# Altium schematic sheet Top.SchDoc(Top)
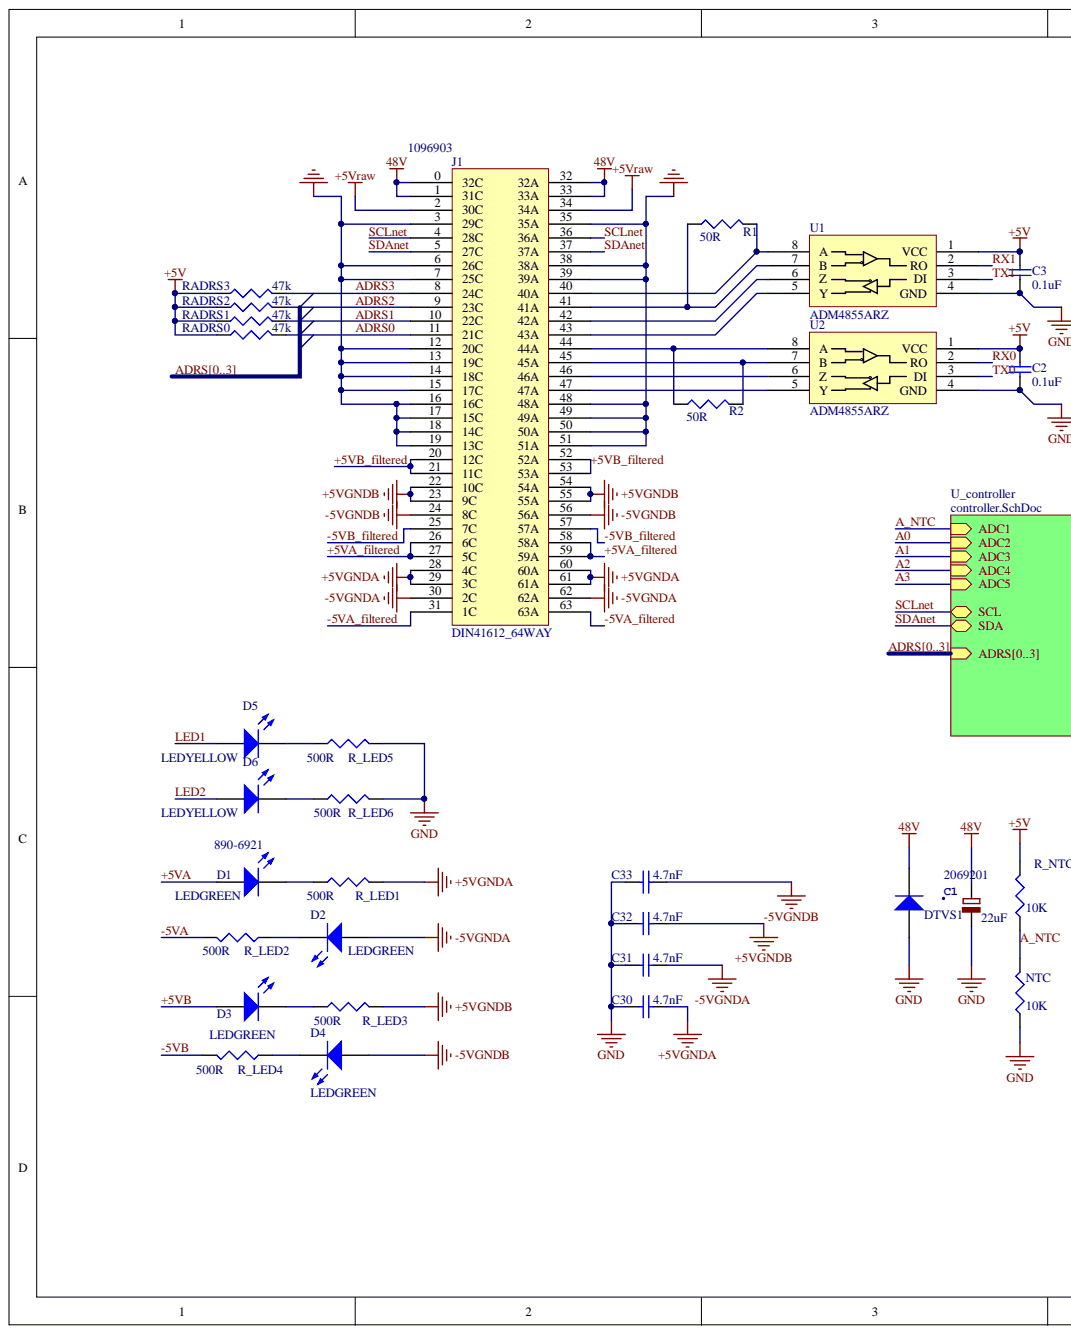
[diagram p0: Top.SchDoc, left]
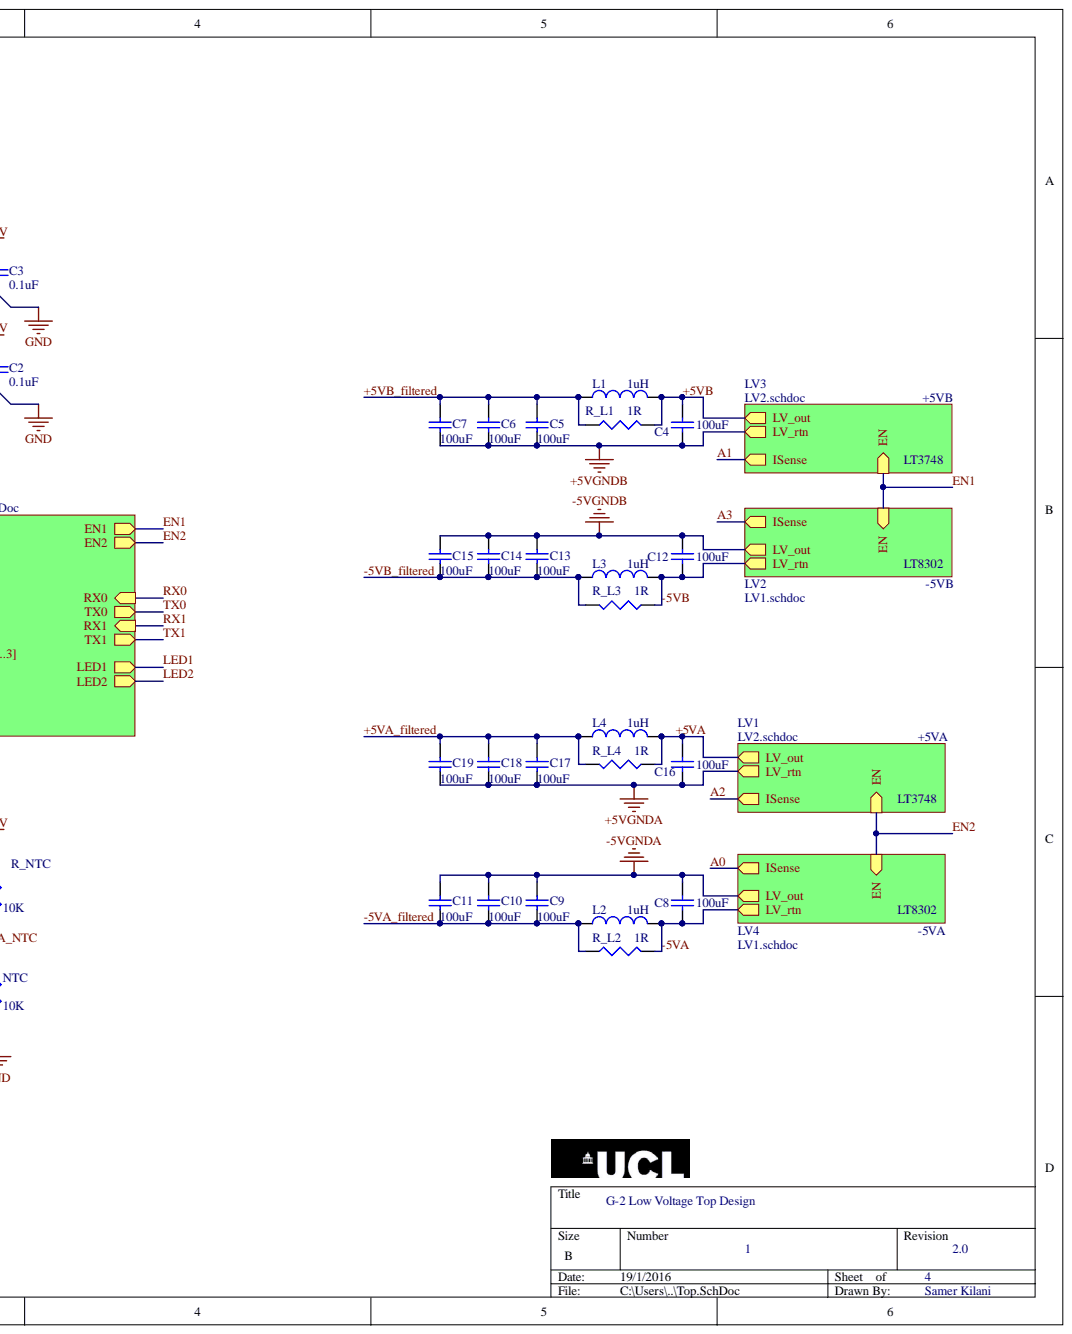
[diagram p1: Top.SchDoc, right]

## Parts
C1  value 22uF  Supplier Part Number 1: 2069201  [PANASONIC_D]
C2  value 0.1uF  [1608[0603]]  (hidden comment: Cap Semi)
C3  value 0.1uF  [1608[0603]]  (hidden comment: Cap Semi)
C4  value 100uF  [C1210]  (hidden comment: Cap Semi)
C5  value 100uF  [C1210]  (hidden comment: Cap Semi)
C6  value 100uF  [C1210]  (hidden comment: Cap Semi)
C7  value 100uF  [C1210]  (hidden comment: Cap Semi)
C8  value 100uF  [C1210]  (hidden comment: Cap Semi)
C9  value 100uF  [C1210]  (hidden comment: Cap Semi)
C10  value 100uF  [C1210]  (hidden comment: Cap Semi)
C11  value 100uF  [C1210]  (hidden comment: Cap Semi)
C12  value 100uF  [C1210]  (hidden comment: Cap Semi)
C13  value 100uF  [C1210]  (hidden comment: Cap Semi)
C14  value 100uF  [C1210]  (hidden comment: Cap Semi)
C15  value 100uF  [C1210]  (hidden comment: Cap Semi)
C16  value 100uF  [C1210]  (hidden comment: Cap Semi)
C17  value 100uF  [C1210]  (hidden comment: Cap Semi)
C18  value 100uF  [C1210]  (hidden comment: Cap Semi)
C19  value 100uF  [C1210]  (hidden comment: Cap Semi)
C30  value 4.7nF  [1812]  (hidden comment: Cap Semi)
C31  value 4.7nF  [1812]  (hidden comment: Cap Semi)
C32  value 4.7nF  [1812]  (hidden comment: Cap Semi)
C33  value 4.7nF  [1812]  (hidden comment: Cap Semi)
D1  LEDGREEN  Supplier Part Number 1: 890-6921  [LEDGREEN]
D2  LEDGREEN  [LEDGREEN]
D3  LEDGREEN  [LEDGREEN]
D4  LEDGREEN  [LEDGREEN]
D5  LEDYELLOW  [LEDYELLOW]
D6  LEDYELLOW  [LEDYELLOW]
DTVS1  SMBJ48A  [DO-214AA]  (value not drawn)
J1  DIN41612_64WAY  Supplier Part Number 1: 1096903  [09 03 164 6919]
L1  value 1uH  [MPLC0730]  (hidden comment: Inductor)
L2  value 1uH  [MPLC0730]  (hidden comment: Inductor)
L3  value 1uH  [MPLC0730]  (hidden comment: Inductor)
L4  value 1uH  [MPLC0730]  (hidden comment: Inductor)
NTC  value 10K  [J1-0603]
R1  value 50R  [J1-0603]
R2  value 50R  [J1-0603]
R_L1  value 1R  [J1-0603]  (hidden comment: Res3)
R_L2  value 1R  [J1-0603]  (hidden comment: Res3)
R_L3  value 1R  [J1-0603]  (hidden comment: Res3)
R_L4  value 1R  [J1-0603]  (hidden comment: Res3)
R_LED1  value 500R  [J1-0603]  (hidden comment: Res3)
R_LED2  value 500R  [J1-0603]  (hidden comment: Res3)
R_LED3  value 500R  [J1-0603]  (hidden comment: Res3)
R_LED4  value 500R  [J1-0603]  (hidden comment: Res3)
R_LED5  value 500R  [J1-0603]  (hidden comment: Res3)
R_LED6  value 500R  [J1-0603]  (hidden comment: Res3)
R_NTC  value 10K  [J1-0603]
RADRS0  value 47k  [J1-0603]  (hidden comment: Res3)
RADRS1  value 47k  [J1-0603]  (hidden comment: Res3)
RADRS2  value 47k  [J1-0603]  (hidden comment: Res3)
RADRS3  value 47k  [J1-0603]  (hidden comment: Res3)
U1  ADM4855ARZ  [SOICN8]
U2  ADM4855ARZ  [SOICN8]

## Nets
48V: C1.1 DTVS1.1 J1.0(32C) J1.1(31C) J1.32(32A) J1.33(33A)
+5V: C2.2 C3.2 R_NTC.2 RADRS0.1 RADRS1.1 RADRS2.1 RADRS3.1 U1.1(VCC) U2.1(VCC)
+5VGNDA: C16.2 C17.2 C18.2 C19.2 C30.2 J1.28(4C) J1.29(3C) J1.60(60A) J1.61(61A) R_LED1.2
+5VGNDB: C4.1 C5.1 C6.1 C7.1 C32.2 J1.22(10C) J1.23(9C) J1.54(54A) J1.55(55A) R_LED3.2
+5VRAW: J1.2(30C) J1.34(34A)
-5VGNDA: C8.2 C9.2 C10.2 C11.2 C31.2 D2.2 J1.30(2C) J1.62(62A)
-5VGNDB: C12.1 C13.1 C14.1 C15.1 C33.2 D4.2 J1.24(8C) J1.56(56A)
GND: C1.2 C2.1 C3.1 C30.1 C31.1 C32.1 C33.1 DTVS1.2 J1.3(29C) J1.6(26C) J1.7(25C) J1.12(20C) J1.13(19C) J1.14(18C) J1.15(17C) J1.16(16C) J1.17(15C) J1.18(14C) J1.19(13C) J1.35(35A) J1.38(38A) J1.39(39A) J1.48(48A) J1.49(49A) J1.50(50A) J1.51(51A) NTC.1 R_LED5.2 R_LED6.2 U1.4(GND) U2.4(GND)
+5VA: C16.1 D1.2 L4.2 R_L4.1
+5VA_FILTERED: C17.1 C18.1 C19.1 J1.26(6C) J1.27(5C) J1.58(58A) J1.59(59A) L4.1 R_L4.2
+5VB: C4.2 D3.2 L1.2 R_L1.2
+5VB_FILTERED: C5.2 C6.2 C7.2 J1.20(12C) J1.21(11C) J1.52(52A) J1.53(53A) L1.1 R_L1.1
-5VA: C8.1 L2.2 R_L2.2 R_LED2.2
-5VA_FILTERED: C9.1 C10.1 C11.1 J1.31(1C) J1.63(63A) L2.1 R_L2.1
-5VB: C12.2 L3.2 R_L3.1 R_LED4.2
-5VB_FILTERED: C13.2 C14.2 C15.2 J1.25(7C) J1.57(57A) L3.1 R_L3.2
A_NTC: NTC.2 R_NTC.1
ADRS0: J1.11(21C) RADRS0.2  [also labelled ADRS]
ADRS1: J1.10(22C) RADRS1.2  [also labelled ADRS]
ADRS2: J1.9(23C) RADRS2.2  [also labelled ADRS]
ADRS3: J1.8(24C) RADRS3.2  [also labelled ADRS]
LED1: D5.2
LED2: D6.2
RX0: U2.2(RO)
RX1: U1.2(RO)
SCLNET: J1.4(28C) J1.36(36A)
SDANET: J1.5(27C) J1.37(37A)
TX0: U2.3(DI)
TX1: U1.3(DI)
NetD1_1: D1.1 R_LED1.1
NetD2_1: D2.1 R_LED2.1
NetD3_1: D3.1 R_LED3.1
NetD4_1: D4.1 R_LED4.1
NetD5_1: D5.1 R_LED5.1
NetD6_1: D6.1 R_LED6.1
NetJ1_40: J1.40(40A) R1.2 U1.8(A)
NetJ1_41: J1.41(41A) R1.1 U1.7(B)
NetJ1_42: J1.42(42A) U1.6(Z)
NetJ1_43: J1.43(43A) U1.5(Y)
NetJ1_44: J1.44(44A) R2.1 U2.8(A)
NetJ1_45: J1.45(45A) R2.2 U2.7(B)
NetJ1_46: J1.46(46A) U2.6(Z)
NetJ1_47: J1.47(47A) U2.5(Y)
A0:
A1:
A2:
A3:
EN1:
EN2:

## Library data of the parts
C1 = CPOL-EUD: PartNo: EMZA500ADA220MF61G; Component Kind: Standard; Library Name: G2_LV.SCHLIB; Supplier 1: Farnell
C2 C3 = Cap Semi: Capacitor (Semiconductor SIM Model); PartNo: CC0603KRX7R9BB104; Component Kind: Standard; LatestRevisionDate: 29-May-2009; LatestRevisionNote: IPC-7351 Footprint Added.; Library Name: Miscellaneous Devices.IntLib; PackageDescription: Chip Capacitor; PackageReference: C1206; Published: 8-Jun-2000; Signal Integrity: Cap Semi; Simulation: CAP; Publisher: Altium Limited
C4 C8 C12 C16 = Cap Semi: Capacitor (Semiconductor SIM Model); PartNo: C3225X5R0J107M250AC; Component Kind: Standard; LatestRevisionDate: 29-May-2009; LatestRevisionNote: IPC-7351 Footprint Added.; Library Name: Miscellaneous Devices.IntLib; PackageDescription: Chip Capacitor; PackageReference: C1206; Published: 8-Jun-2000; Signal Integrity: Cap Semi; Simulation: CAP; Publisher: Altium Limited
C5-C7 C9-C11 C13-C15 C17-C19 = Cap Semi: Capacitor (Semiconductor SIM Model); PartNo: CL32A107MPVNNNE; Component Kind: Standard; LatestRevisionDate: 29-May-2009; LatestRevisionNote: IPC-7351 Footprint Added.; Library Name: Miscellaneous Devices.IntLib; PackageDescription: Chip Capacitor; PackageReference: C1206; Published: 8-Jun-2000; Signal Integrity: Cap Semi; Simulation: CAP; Publisher: Altium Limited
C30-C33 = Cap Semi: Capacitor (Semiconductor SIM Model); PartNo: C1812C472KDRACTU; Component Kind: Standard; LatestRevisionDate: 29-May-2009; LatestRevisionNote: IPC-7351 Footprint Added.; Library Name: G2_LV.SCHLIB; PackageDescription: Chip Capacitor; PackageReference: C1206; Published: 8-Jun-2000; Signal Integrity: Cap Semi; Simulation: CAP; Publisher: Altium Limited
D1-D4 = LEDGREEN: PartNo: 550-0207F; Component Kind: Standard; Library Name: G2_LV.SCHLIB
  D1: Supplier 1: RS-Components
D5 D6 = LEDYELLOW: PartNo: 550-0307F; Component Kind: Standard; Library Name: G2_LV.SCHLIB
DTVS1 = SMBJ: TVS; Note: Pin 2 is not connected and not drawn.; Component Kind: Standard; ComponentLink1Description: Manufacturer Link; ComponentLink1URL: http://www.infineon.com/; ComponentLink2Description: Datasheet; ComponentLink2URL: http://www.infineon.com/upload/Document/cmc_upload/documents/080/656/bas116series.pdf; DatasheetVersion: Oct-1999; LatestRevisionDate: 29-May-2009; LatestRevisionNote: IPC-7351 Footprint Added.; Library Name: G2_LV.IntLib; PackageDescription: 3-Pin SOT-23 Package 0.95 mm Pitch, 2.5 mm Lead Span; PackageReference: SOT-23; PackageVersion: Sep-2001; PartNo: SMBJ48A; Published: 21-Mar-2003; Publisher: Altium Limited
J1 = DIN41612_64WAY: PartNo: 0903 164 6921; Component Kind: Standard; Library Name: G2_LV.SCHLIB; Supplier 1: Farnell
L1-L4 = Inductor: PartNo: MPLC0730L1R0; Code_IEC: 1005; Code_JEITA: 0402; Component Kind: Standard; Description: Inductor; LatestRevisionDate: 29-May-2009; LatestRevisionNote: IPC-7351 Footprint Added.; Library Name: G2_LV.SCHLIB; PackageDescription: Chip Inductor; PackageReference: 0402-A; Published: 8-Jun-2000; Publisher: Altium Limited
NTC = Res3: Resistor; PartNo: NCP18XH103J03RB; Component Kind: Standard; LatestRevisionDate: 29-May-2009; LatestRevisionNote: IPC-7351 Footprint Added.; Library Name: Miscellaneous Devices.IntLib; PackageDescription: Chip Resistor; PackageReference: J1-0603; Published: 8-Jun-2000; Signal Integrity: Res; Simulation: RESISTOR; Publisher: Altium Limited
R1 R2 R_L1-R_L4 R_LED1-R_LED6 R_NTC RADRS0-RADRS3 = Res3: Resistor; Component Kind: Standard; LatestRevisionDate: 29-May-2009; LatestRevisionNote: IPC-7351 Footprint Added.; Library Name: Miscellaneous Devices.IntLib; PackageDescription: Chip Resistor; PackageReference: J1-0603; Published: 8-Jun-2000; Signal Integrity: Res; Simulation: RESISTOR; Publisher: Altium Limited
U1 U2 = SP3084EEN-L: Component Kind: Standard; PartNo: ADM4855ARZ; Library Name: BACKPLANElib.SchLib
